# Altium schematic sheet pyPico.SchDoc(pyPico)
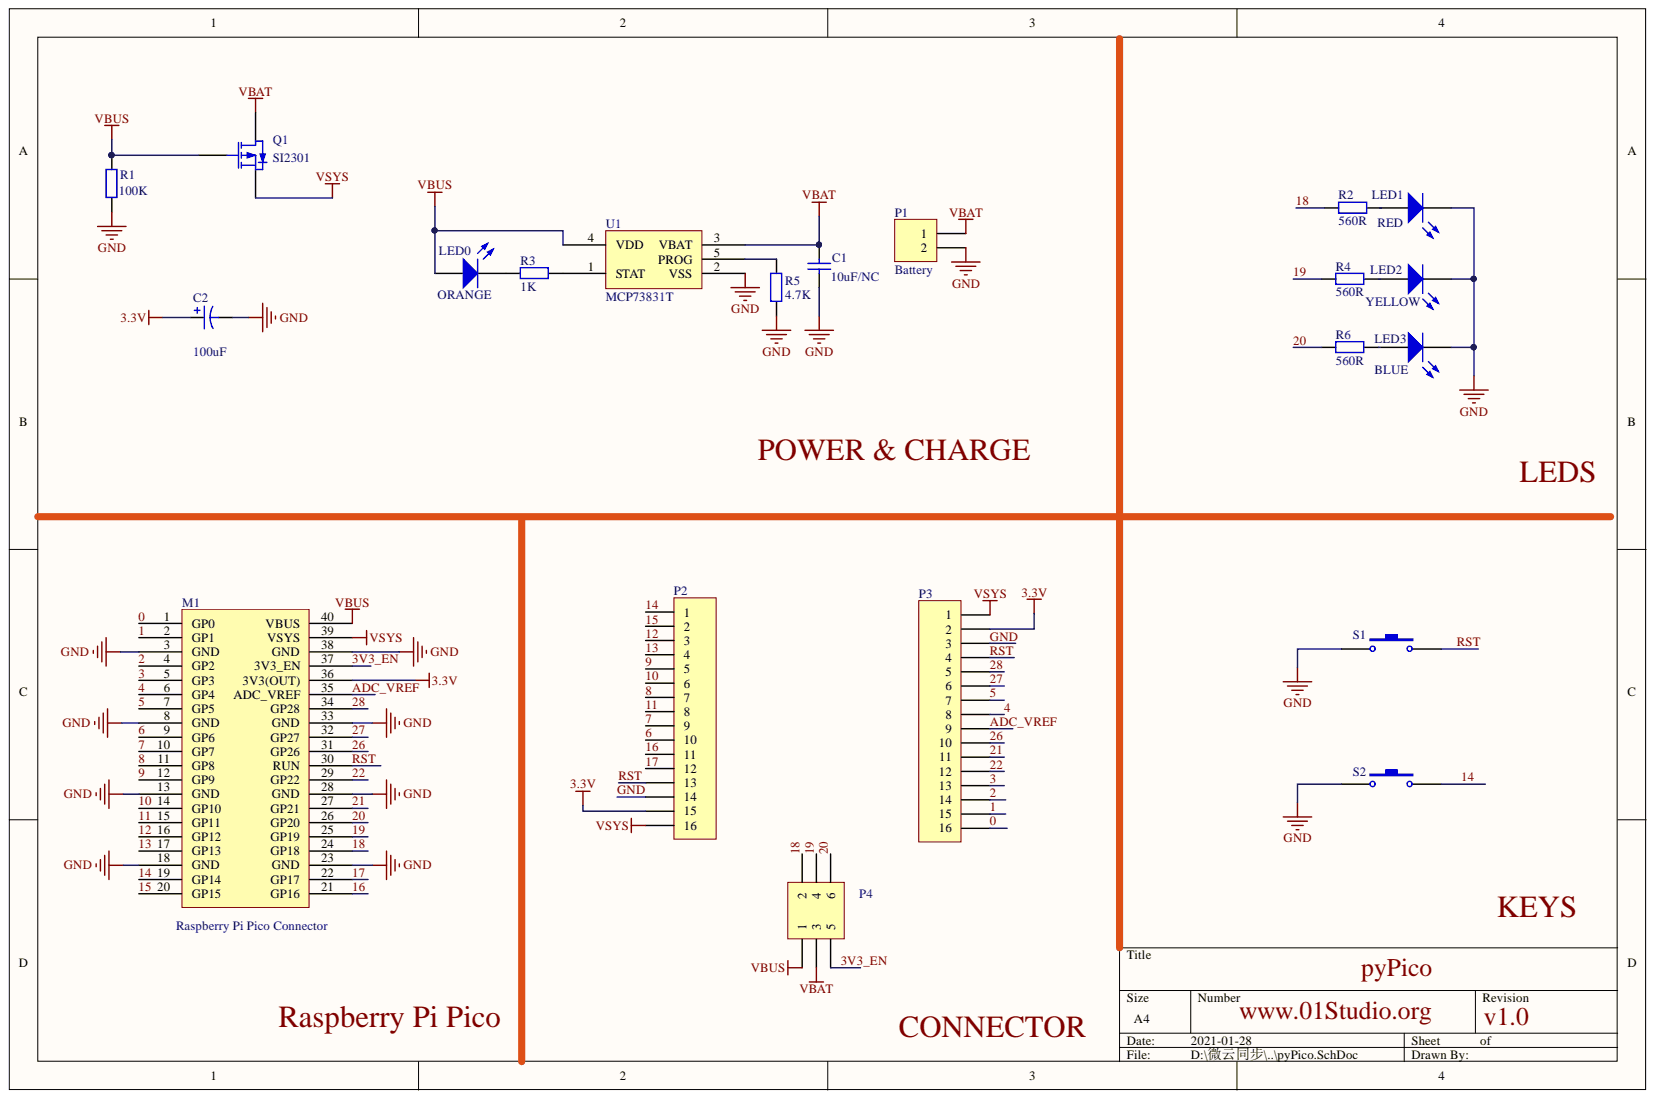
[diagram p0: pyPico.SchDoc]

## Parts
C1  value 10uF/NC  [C0603]
C2  value 100uF  [B型钽电容]
LED0  ORANGE  [D0603]
LED1  RED  [D0603]
LED2  YELLOW  [D0603]
LED3  BLUE  [D0603]
M1  Raspberry Pi Pico Connector  [Raspberry Pi Pico Con]
P1  Battery  [XH2.54-2P/卧贴]
P2  (no value)  [1*16P/2.54]
P3  (no value)  [1*16P/2.54]
P4  (no value)  [HDR2X3]
Q1  SI2301  [SOT-23]
R1  value 100K  [R0603]
R2  560R  [R0603]
R3  value 1K  [R0603]
R4  560R  [R0603]
R5  value 4.7K  [R0603]
R6  560R  [R0603]
S1  (no value)  [贴片按键/3.2*4.2*2.5mm]
S2  (no value)  [贴片按键/3.2*4.2*2.5mm]
U1  MCP73831T  [SOT23-5]

## Nets
3.3V: C2.1 M1.36(3V3(OUT)) P2.15 P3.2
VBAT: C1.2 P1.1 P4.3 Q1.3 U1.3(VBAT)
VBUS: LED0.1 M1.40(VBUS) P4.1 Q1.1 R1.1 U1.4(VDD)
VSYS: M1.39(VSYS) P2.16 P3.1 Q1.2
0: M1.1(GP0) P3.16
1: M1.2(GP1) P3.15
2: M1.4(GP2) P3.14
3: M1.5(GP3) P3.13
3V3_EN: M1.37(3V3_EN) P4.5
4: M1.6(GP4) P3.8
5: M1.7(GP5) P3.7
6: M1.9(GP6) P2.10
7: M1.10(GP7) P2.9
8: M1.11(GP8) P2.7
9: M1.12(GP9) P2.5
10: M1.14(GP10) P2.6
11: M1.15(GP11) P2.8
12: M1.16(GP12) P2.3
13: M1.17(GP13) P2.4
14: M1.19(GP14) P2.1 S2.2
15: M1.20(GP15) P2.2
16: M1.21(GP16) P2.11
17: M1.22(GP17) P2.12
18: M1.24(GP18) P4.2 R2.1
19: M1.25(GP19) P4.4 R4.1
20: M1.26(GP20) P4.6 R6.1
21: M1.27(GP21) P3.11
22: M1.29(GP22) P3.12
26: M1.31(GP26) P3.10
27: M1.32(GP27) P3.6
28: M1.34(GP28) P3.5
ADC_VREF: M1.35(ADC_VREF) P3.9
GND: C1.1 C2.2 LED1.2 LED2.2 LED3.2 M1.3(GND) M1.8(GND) M1.13(GND) M1.18(GND) M1.23(GND) M1.28(GND) M1.33(GND) M1.38(GND) P1.2 P2.14 P3.3 R1.2 R5.1 S1.1 S2.1 U1.2(VSS)
RST: M1.30(RUN) P2.13 P3.4 S1.2
NetLED0_2: LED0.2 R3.1
NetLED1_1: LED1.1 R2.2
NetLED2_1: LED2.1 R4.2
NetLED3_1: LED3.1 R6.2
NetR3_2: R3.2 U1.1(STAT)
NetR5_2: R5.2 U1.5(PROG)

## Library data of the parts
C1 = Cap: Capacitor; Simulation: CAP; Signal Integrity: Cap; Published: 8-Jun-2000; PackageReference: RAD-0.3; Library Name: Miscellaneous Devices.IntLib; LatestRevisionNote: Re-released for DXP Platform.; LatestRevisionDate: 17-Jul-2002; Component Kind: Standard; Publisher: Altium Limited
C2 = Cap Pol1: Polarized Capacitor (Radial); Simulation: CAP; Signal Integrity: Cap Pol; Published: 8-Jun-2000; PackageReference: RB7.6-15; Library Name: Miscellaneous Devices.IntLib; LatestRevisionNote: Re-released for DXP Platform.; LatestRevisionDate: 17-Jul-2002; Component Kind: Standard; Publisher: Altium Limited
LED0-LED3 = LED0: Typical INFRARED GaAs LED; Simulation: LED0; Published: 8-Jun-2000; PackageReference: LED-0; Library Name: Miscellaneous Devices.IntLib; LatestRevisionNote: Mech Layer 1 removed.; LatestRevisionDate: 08-Jul-2005; Component Kind: Standard; Publisher: Altium Limited
  LED0: PackageDescription: LED; 2 Leads
P1 = MHDR1X2: Header, 2-Pin; Signal Integrity: Connector; Library Name: Miscellaneous Connectors.IntLib; LatestRevisionNote: Re-released for DXP Platform.; LatestRevisionDate: 17-Jul-2002; Component Kind: Standard; Publisher: Altium Limited
P2 P3 = Header 16: Header, 16-Pin; Signal Integrity: Connector; Library Name: Miscellaneous Connectors.IntLib; LatestRevisionNote: Re-released for DXP Platform.; LatestRevisionDate: 17-Jul-2002; Component Kind: Standard; Publisher: Altium Limited
P4 = MHDR2X3: Header, 3-Pin, Dual row; Signal Integrity: Connector; Library Name: Miscellaneous Connectors.IntLib; LatestRevisionNote: Re-released for DXP Platform.; LatestRevisionDate: 17-Jul-2002; Component Kind: Standard; Publisher: Altium Limited
Q1 = P-MOS: Library Name: WeBee.SCHLIB; Component Kind: Standard
R1-R6 = Res2: Resistor; Simulation: RESISTOR; Signal Integrity: Res; Published: 8-Jun-2000; PackageReference: AXIAL-0.4; Library Name: Miscellaneous Devices.IntLib; LatestRevisionNote: Re-released for DXP Platform.; LatestRevisionDate: 17-Jul-2002; Component Kind: Standard; Publisher: Altium Limited
S1 S2 = SW-PB: Switch; Published: 24-Mar-1999; PackageReference: SPST-2; Library Name: Miscellaneous Devices.IntLib; LatestRevisionNote: Re-released for DXP Platform.; LatestRevisionDate: 17-Jul-2002; Component Kind: Standard; Publisher: Altium Limited
M1 = Raspberry Pi Pico Connector: Library Name: 01Studio.SchLib; Component Kind: Standard
U1 = MCP73831T: Component Kind: Standard
